# Altium schematic sheet Bomba_Refri.SchDoc(Bomba_Refri)
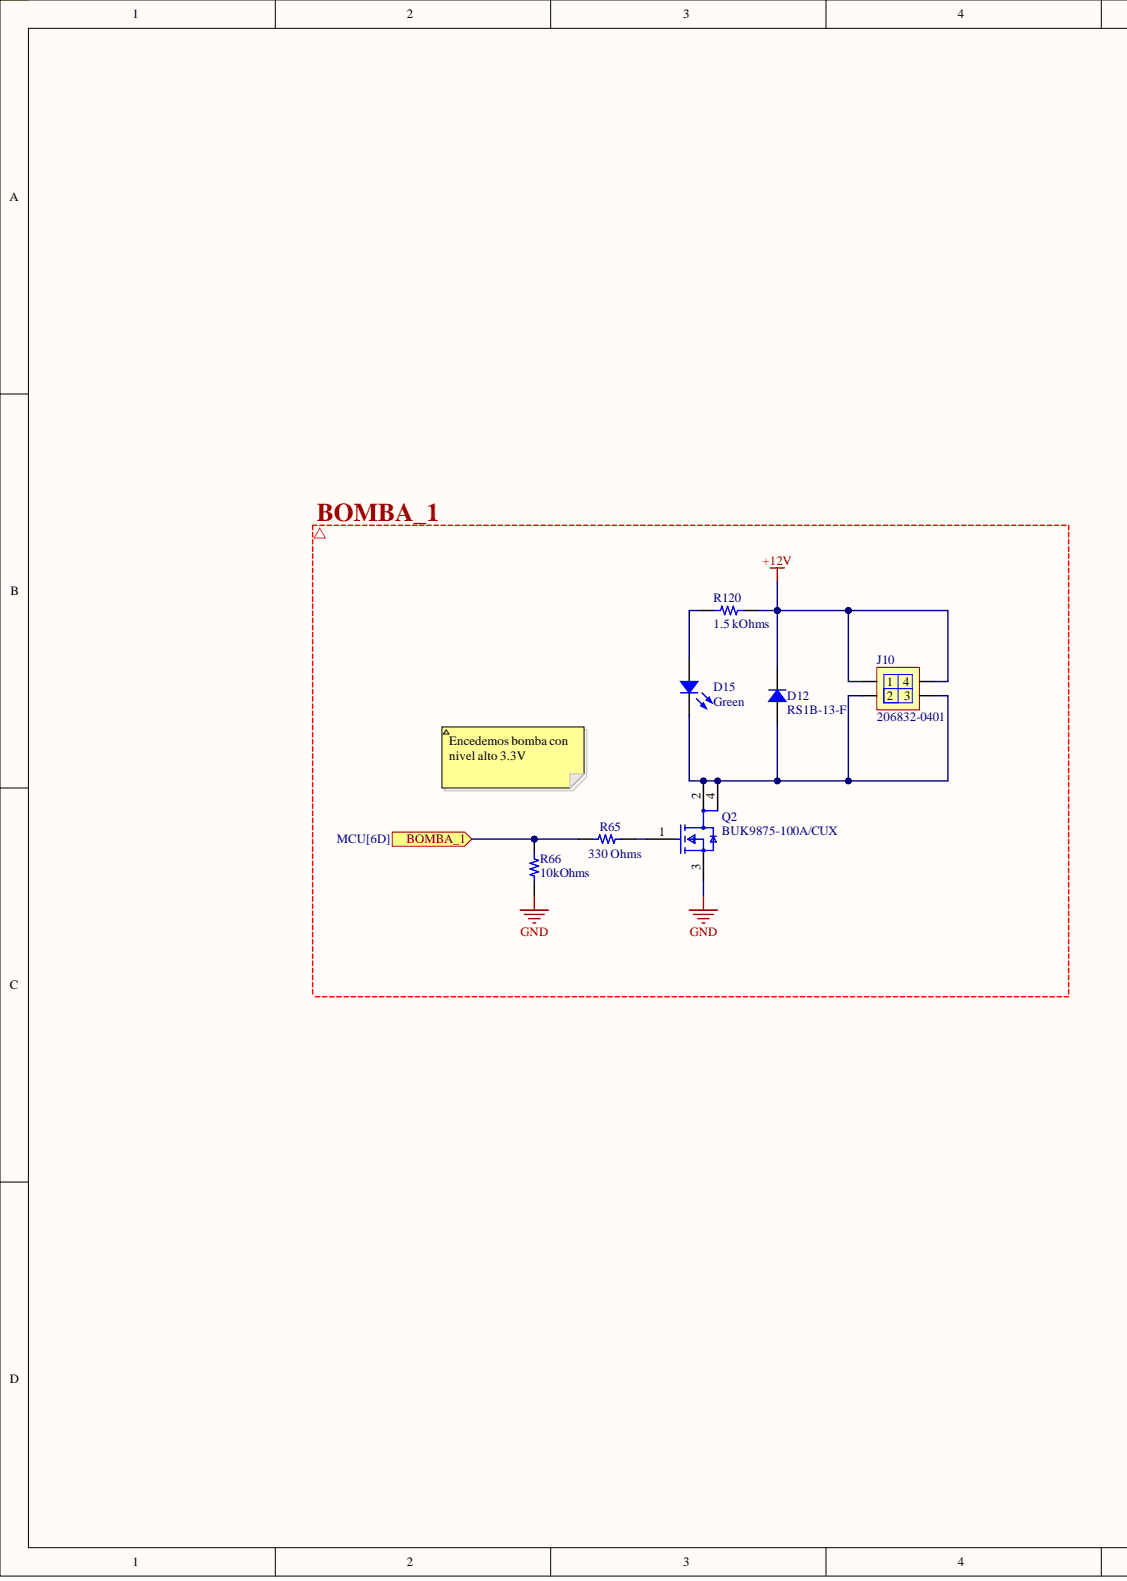
[diagram p0: Bomba_Refri.SchDoc, left]
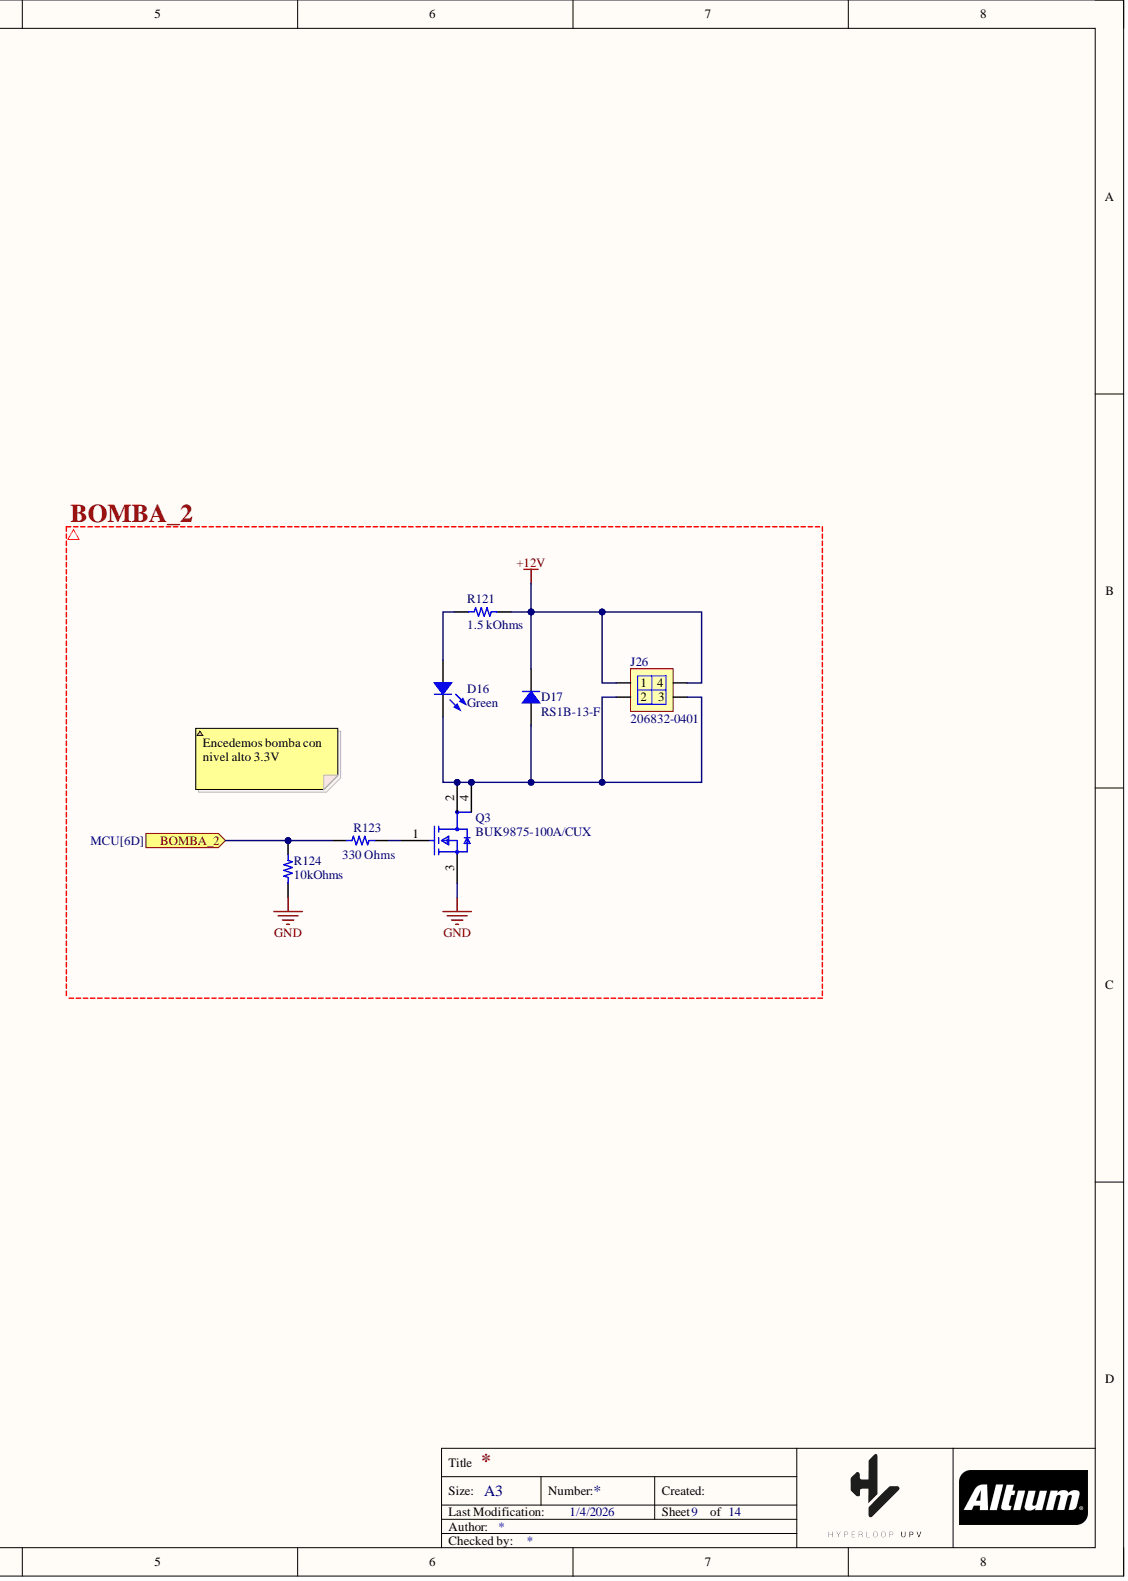
[diagram p1: Bomba_Refri.SchDoc, right]

## Parts
D12  RS1B-13-F
D15  Green
D16  Green
D17  RS1B-13-F
J10  206832-0401
J26  206832-0401
Q2  BUK9875-100A/CUX
Q3  BUK9875-100A/CUX
R65  330 Ohms
R66  10kOhms
R120  1.5 kOhms
R121  1.5 kOhms
R123  330 Ohms
R124  10kOhms

## Ports
BOMBA_1, BOMBA_2

## Nets
+12V: D12.2 D17.2 J10.1 J10.4 J26.1 J26.4 R120.2 R121.2
GND: Q2.3 Q3.3 R66.1 R124.1
BOMBA_1: R65.1 R66.2 ; port BOMBA_1
BOMBA_2: R123.1 R124.2 ; port BOMBA_2
NetD12_1: D12.1 D15.2 J10.2 J10.3 Q2.2 Q2.4
NetD15_1: D15.1 R120.1
NetD16_1: D16.1 R121.1
NetD16_2: D16.2 D17.1 J26.2 J26.3 Q3.2 Q3.4
NetQ2_1: Q2.1 R65.2
NetQ3_1: Q3.1 R123.2

## Library data of the parts
D12 D17 = RS1B-13-F: DIODE GEN PURP 100V 1A SMA; Manufacturer: Diodes Incorporated; Capacitance @ Vr, F: 15pF @ 4V, 1MHz; Current - Reverse Leakage @ Vr: 5 µA @ 100 V; Database Table Name: Diodes - Rectifiers - Single; Diode Type: Standard; Reverse Recovery Time (trr): 150 ns; Speed: Fast Recovery =< 500ns, > 200mA (Io); Voltage - Forward (Vf) (Max) @ If: 1.3 V @ 1 A; Component Kind: Standard; ComponentLink1Description: Datasheet; ComponentLink1URL: https://www.diodes.com/assets/Datasheets/ds15002.pdf; ComponentLink2Description: DigiKey Link; ComponentLink2URL: https://www.digikey.com/en/products/detail/diodes-incorporated/RS1B-13-F/717796; Current - Average Rectified (Io): 1A; LastUpdated: 19/09/2022 13:46:17; Library Name: Celestial Altium Library - altium_library.DbLib; Lifecycle Status: Active; Minimum Order: 1; Mounting Type: Surface Mount; Operating Temperature - Junction: -65°C ~ 150°C; Package / Case: DO-214AC, SMA; Packaging: TapeAndReel; Part Number: RS1B-13-F; Part Status: Active; PartId: 624; Price: 0.06; Series: -; Supplier 1: DigiKey; Supplier Device Package: SMA; Supplier Part Number 1: 31-RS1B-13-FCT-ND; Voltage - DC Reverse (Vr) (Max): 100 V
D15 D16 = QTLP601C4TR: LED GREEN CLEAR 0603 SMD; Manufacturer: Everlight Electronics Co Ltd; Configuration: Standard; Current - Test: 20mA; Database Table Name: LED - Indication; Lens Color: Colorless; Lens Size: 1.20mm x 0.80mm; Lens Style: Rectangle with Flat Top; Millicandela Rating: 15mcd; Viewing Angle: 120°; Voltage - Forward (Vf) (Typ): 2.1V; Wavelength - Dominant: 570nm; Wavelength - Peak: 565nm; Color: Green; Component Kind: Standard; ComponentLink1Description: Datasheet; ComponentLink1URL: //media.digikey.com/pdf/Data%20Sheets/Everlight%20PDFs/QTLP601C-2,3,4,7,B.pdf; ComponentLink2Description: DigiKey Link; ComponentLink2URL: https://www.digikey.com/en/products/detail/everlight-electronics-co-ltd/QTLP601C4TR/2675896; Device Package: 0603 (1608 Metric); Height (Max): 0.60mm; LastUpdated: 19/09/2022 13:49:59; Lens Transparency: Clear; Library Name: Celestial Altium Library - altium_library.DbLib; Lifecycle Status: Active; Minimum Order: 1; Mounting Type: Surface Mount; Package / Case: 0603 (1608 Metric); Packaging: TapeAndReel; Part Number: QTLP601C4TR; Part Status: Active; PartId: 584; Price: 0.05; Series: -; Size / Dimension: 1.60mm L x 0.80mm W; Supplier 1: DigiKey; Supplier Device Package: 0603; Supplier Part Number 1: 1080-1405-1-ND; Value: Green
J10 J26 = CMP-006-00301-4: MICRO-FIT Plus Header Assembly Dual Row 4 Position Straight Tin; Connector Type: Micro-Fit+; Gender: Male; Max Current: 12.5 A; Max Voltage: 600V AC (RMS)/DC; Component Kind: Standard; Max Operating Temperature: 105°C; Min Operating Temperature: -40°C; Mounting Technology: THT; Pins: 4; RoHS Compliant: Yes; Library Name: Hyperloop UPV
Q2 Q3 = BUK9875-100A/CUX-1: Power Field-Effect Transistor, 7A I(D), 100V, 0.084ohm, 1-Element, N-Channel, Silicon, Metal-oxide Semiconductor FET; Component Kind: Standard; RoHS Compliant: Yes; Library Name: Hyperloop UPV
R65 R123 = CRGCQ0603F330R: RES 330 OHM 1% 1/10W 0603; Manufacturer: TE Connectivity Passive Product; Database Table Name: Resistors - Surface Mount - 0603; Power (Watts): 0.1W, 1/10W; Temperature Coefficient: ±100ppm/°C; Component Kind: Standard; ComponentLink1Description: Datasheet; ComponentLink1URL: https://www.te.com/commerce/DocumentDelivery/DDEController?Action=srchrtrv&DocNm=1773204-3&DocType=DS&DocLang=English; ComponentLink2Description: DigiKey Link; ComponentLink2URL: https://www.digikey.com/en/products/detail/te-connectivity-passive-product/CRGCQ0603F330R/8576284; Composition: Thick Film; Device Package: 0603 (1608 Metric); Features: Automotive AEC-Q200, Moisture Resistant; Height - Seated (Max): 0.022" (0.55mm); LastUpdated: 19/09/2022 13:21:15; Library Name: Celestial Altium Library - altium_library.DbLib; Lifecycle Status: Active; Minimum Order: 1; Number of Terminations: 2; Operating Temperature: -55°C ~ 155°C; Package / Case: 0603 (1608 Metric); Packaging: CutTape; Part Number: CRGCQ0603F330R; Part Status: Active; PartId: 24001; Price: 0.01; Ratings: AEC-Q200; Resistance: 330 Ohms; Series: CRGCQ; Size / Dimension: 0.063" L x 0.031" W (1.60mm x 0.80mm); Supplier 1: DigiKey; Supplier Device Package: 0603; Supplier Part Number 1: A129682CT-ND; Tolerance: ±1%; Value: 330 Ohms
R66 R124 = CRGCQ0603F330R: RES 330 OHM 1% 1/10W 0603; Manufacturer: TE Connectivity Passive Product; Database Table Name: Resistors - Surface Mount - 0603; Power (Watts): 0.1W, 1/10W; Resistance: 330 Ohms; Temperature Coefficient: ±100ppm/°C; Component Kind: Standard; ComponentLink1Description: Datasheet; ComponentLink1URL: https://www.te.com/commerce/DocumentDelivery/DDEController?Action=srchrtrv&DocNm=1773204-3&DocType=DS&DocLang=English; ComponentLink2Description: DigiKey Link; ComponentLink2URL: https://www.digikey.com/en/products/detail/te-connectivity-passive-product/CRGCQ0603F330R/8576284; Composition: Thick Film; Device Package: 0603 (1608 Metric); Features: Automotive AEC-Q200, Moisture Resistant; Height - Seated (Max): 0.022" (0.55mm); LastUpdated: 19/09/2022 13:21:15; Library Name: Celestial Altium Library - altium_library.DbLib; Lifecycle Status: Active; Minimum Order: 1; Number of Terminations: 2; Operating Temperature: -55°C ~ 155°C; Package / Case: 0603 (1608 Metric); Packaging: CutTape; Part Number: CRGCQ0603F330R; Part Status: Active; PartId: 24001; Price: 0.01; Ratings: AEC-Q200; Series: CRGCQ; Size / Dimension: 0.063" L x 0.031" W (1.60mm x 0.80mm); Supplier 1: DigiKey; Supplier Device Package: 0603; Supplier Part Number 1: A129682CT-ND; Tolerance: ±1%; Value: 10kOhms
R120 R121 = RNCS0603BKE10K0: RES 10K OHM 0.1% 1/16W 0603; Manufacturer: Stackpole Electronics Inc; Database Table Name: Resistors - Surface Mount - 0603; Power (Watts): 0.063W, 1/16W; Resistance: 10 kOhms; Temperature Coefficient: ±25ppm/°C; Component Kind: Standard; ComponentLink1Description: Datasheet; ComponentLink1URL: https://www.seielect.com/catalog/sei-rncs_rnch.pdf; ComponentLink2Description: DigiKey Link; ComponentLink2URL: https://www.digikey.com/en/products/detail/stackpole-electronics-inc/RNCS0603BKE10K0/1708836; Composition: Thin Film; Device Package: 0603 (1608 Metric); Features: Anti-Corrosive, Automotive AEC-Q200, Moisture Resistant; Height - Seated (Max): 0.022" (0.55mm); LastUpdated: 09-Feb-24 20:43:37; Library Name: Celestial Altium Library - altium_library.DbLib; Lifecycle Status: Active; Minimum Order: 1; Number of Terminations: 2; Operating Temperature: -55°C ~ 155°C; Package / Case: 0603 (1608 Metric); Packaging: TapeAndReel; Part Number: RNCS0603BKE10K0; Part Status: Active; PartId: 4838; Price: 0.06; Ratings: AEC-Q200; Series: RNCS; Size / Dimension: 0.061" L x 0.031" W (1.55mm x 0.80mm); Supplier 1: DigiKey; Supplier Device Package: 0603; Supplier Part Number 1: RNCS0603BKE10K0CT-ND; Tolerance: ±0.1%; Value: 1.5 kOhms
